# Altium schematic sheet 08 - MICROHEADERS - JX1 and JX2.SchDoc("08 - MICROHEADERS - JX1 and JX2")
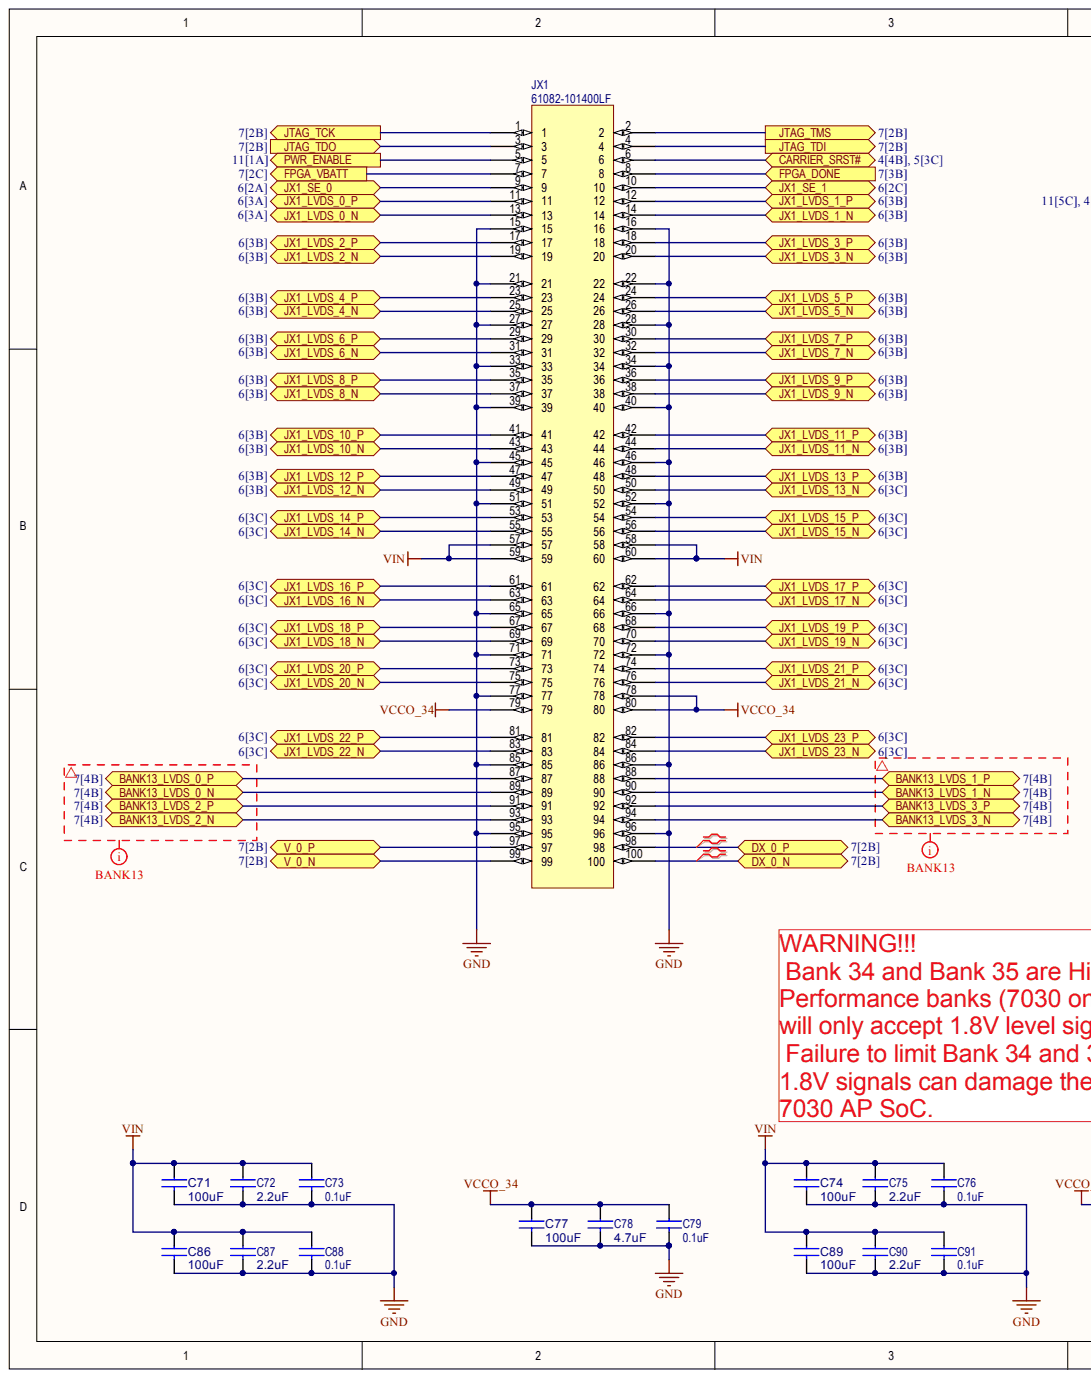
[diagram p0: 08 - MICROHEADERS - JX1 and JX2.SchDoc, left]
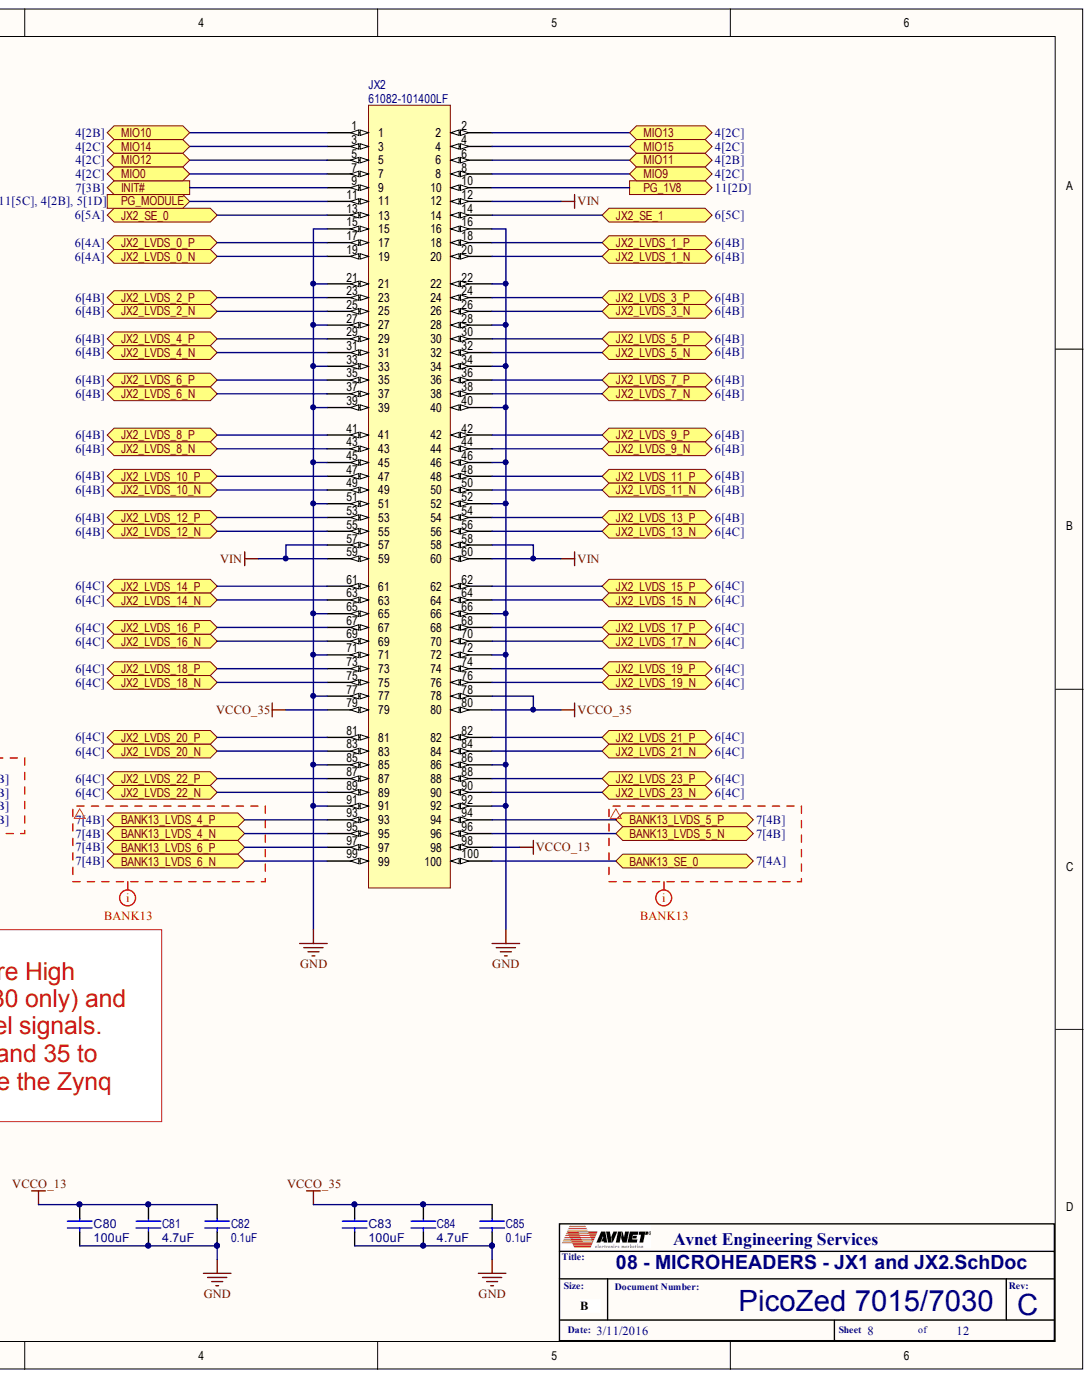
[diagram p1: 08 - MICROHEADERS - JX1 and JX2.SchDoc, right]

## Parts
C71  100uF  [C1210]
C72  2.2uF  [C0402]
C73  value 0.1uF  [C0201]  (hidden comment: PC522)
C74  100uF  [C1210]
C75  2.2uF  [C0402]
C76  value 0.1uF  [C0201]  (hidden comment: PC522)
C77  100uF  [C1210]
C78  4.7uF  [C0402]
C79  value 0.1uF  [C0201]  (hidden comment: PC522)
C80  100uF  [C1210]
C81  4.7uF  [C0402]
C82  value 0.1uF  [C0201]  (hidden comment: PC522)
C83  100uF  [C1210]
C84  4.7uF  [C0402]
C85  value 0.1uF  [C0201]  (hidden comment: PC522)
C86  100uF  [C1210]
C87  2.2uF  [C0402]
C88  value 0.1uF  [C0201]  (hidden comment: PC522)
C89  100uF  [C1210]
C90  2.2uF  [C0402]
C91  value 0.1uF  [C0201]  (hidden comment: PC522)
JX1  61082-101400LF  [61082-101400LF]
JX2  61082-101400LF  [61082-101400LF]

## Ports
BANK13_LVDS_0_N, BANK13_LVDS_0_P, BANK13_LVDS_1_N, BANK13_LVDS_1_P, BANK13_LVDS_2_N, BANK13_LVDS_2_P, BANK13_LVDS_3_N, BANK13_LVDS_3_P, BANK13_LVDS_4_N, BANK13_LVDS_4_P, BANK13_LVDS_5_N, BANK13_LVDS_5_P, BANK13_LVDS_6_N, BANK13_LVDS_6_P, BANK13_SE_0, CARRIER_SRST#, DX_0_N, DX_0_P, FPGA_DONE, FPGA_VBATT, INIT#, JTAG_TCK, JTAG_TDI, JTAG_TDO, JTAG_TMS, JX1_LVDS_0_N, JX1_LVDS_0_P, JX1_LVDS_1_N, JX1_LVDS_1_P, JX1_LVDS_2_N, JX1_LVDS_2_P, JX1_LVDS_3_N, JX1_LVDS_3_P, JX1_LVDS_4_N, JX1_LVDS_4_P, JX1_LVDS_5_N, JX1_LVDS_5_P, JX1_LVDS_6_N, JX1_LVDS_6_P, JX1_LVDS_7_N, JX1_LVDS_7_P, JX1_LVDS_8_N, JX1_LVDS_8_P, JX1_LVDS_9_N, JX1_LVDS_9_P, JX1_LVDS_10_N, JX1_LVDS_10_P, JX1_LVDS_11_N, JX1_LVDS_11_P, JX1_LVDS_12_N, JX1_LVDS_12_P, JX1_LVDS_13_N, JX1_LVDS_13_P, JX1_LVDS_14_N, JX1_LVDS_14_P, JX1_LVDS_15_N, JX1_LVDS_15_P, JX1_LVDS_16_N, JX1_LVDS_16_P, JX1_LVDS_17_N, JX1_LVDS_17_P, JX1_LVDS_18_N, JX1_LVDS_18_P, JX1_LVDS_19_N, JX1_LVDS_19_P, JX1_LVDS_20_N, JX1_LVDS_20_P, JX1_LVDS_21_N, JX1_LVDS_21_P, JX1_LVDS_22_N, JX1_LVDS_22_P, JX1_LVDS_23_N, JX1_LVDS_23_P, JX1_SE_0, JX1_SE_1, JX2_LVDS_0_N, JX2_LVDS_0_P, JX2_LVDS_1_N, JX2_LVDS_1_P, JX2_LVDS_2_N, JX2_LVDS_2_P, JX2_LVDS_3_N, JX2_LVDS_3_P, JX2_LVDS_4_N, JX2_LVDS_4_P, JX2_LVDS_5_N, JX2_LVDS_5_P, JX2_LVDS_6_N, JX2_LVDS_6_P, JX2_LVDS_7_N, JX2_LVDS_7_P, JX2_LVDS_8_N, JX2_LVDS_8_P, JX2_LVDS_9_N, JX2_LVDS_9_P, JX2_LVDS_10_N, JX2_LVDS_10_P, JX2_LVDS_11_N, JX2_LVDS_11_P, JX2_LVDS_12_N, JX2_LVDS_12_P, JX2_LVDS_13_N, JX2_LVDS_13_P, JX2_LVDS_14_N, JX2_LVDS_14_P, JX2_LVDS_15_N, JX2_LVDS_15_P, JX2_LVDS_16_N, JX2_LVDS_16_P, JX2_LVDS_17_N, JX2_LVDS_17_P, JX2_LVDS_18_N, JX2_LVDS_18_P, JX2_LVDS_19_N, JX2_LVDS_19_P, JX2_LVDS_20_N, JX2_LVDS_20_P, JX2_LVDS_21_N, JX2_LVDS_21_P, JX2_LVDS_22_N, JX2_LVDS_22_P, JX2_LVDS_23_N, JX2_LVDS_23_P, JX2_SE_0, JX2_SE_1, MIO0, MIO9, MIO10, MIO11, MIO12, MIO13, MIO14, MIO15, PG_1V8, PG_MODULE, PWR_ENABLE, V_0_N, V_0_P

## Nets
GND: C71.2 C72.2 C73.2 C74.2 C75.2 C76.2 C77.2 C78.2 C79.2 C80.2 C81.2 C82.2 C83.2 C84.2 C85.2 C86.2 C87.2 C88.2 C89.2 C90.2 C91.2 JX1.15 JX1.16 JX1.21 JX1.22 JX1.27 JX1.28 JX1.33 JX1.34 JX1.39 JX1.40 JX1.45 JX1.46 JX1.51 JX1.52 JX1.65 JX1.66 JX1.71 JX1.72 JX1.77 JX1.85 JX1.86 JX1.95 JX1.96 JX2.15 JX2.16 JX2.21 JX2.22 JX2.27 JX2.28 JX2.33 JX2.34 JX2.39 JX2.40 JX2.45 JX2.46 JX2.51 JX2.52 JX2.65 JX2.66 JX2.71 JX2.72 JX2.77 JX2.85 JX2.86 JX2.91 JX2.92
VCCO_13: C80.1 C81.1 C82.1 JX2.98
VCCO_34: C77.1 C78.1 C79.1 JX1.78 JX1.79 JX1.80
VCCO_35: C83.1 C84.1 C85.1 JX2.78 JX2.79 JX2.80
VIN: C71.1 C72.1 C73.1 C74.1 C75.1 C76.1 C86.1 C87.1 C88.1 C89.1 C90.1 C91.1 JX1.57 JX1.58 JX1.59 JX1.60 JX2.12 JX2.57 JX2.58 JX2.59 JX2.60
BANK13_LVDS_0_N: JX1.89 ; port BANK13_LVDS_0_N
BANK13_LVDS_0_P: JX1.87 ; port BANK13_LVDS_0_P
BANK13_LVDS_1_N: JX1.90 ; port BANK13_LVDS_1_N
BANK13_LVDS_1_P: JX1.88 ; port BANK13_LVDS_1_P
BANK13_LVDS_2_N: JX1.93 ; port BANK13_LVDS_2_N
BANK13_LVDS_2_P: JX1.91 ; port BANK13_LVDS_2_P
BANK13_LVDS_3_N: JX1.94 ; port BANK13_LVDS_3_N
BANK13_LVDS_3_P: JX1.92 ; port BANK13_LVDS_3_P
BANK13_LVDS_4_N: JX2.95 ; port BANK13_LVDS_4_N
BANK13_LVDS_4_P: JX2.93 ; port BANK13_LVDS_4_P
BANK13_LVDS_5_N: JX2.96 ; port BANK13_LVDS_5_N
BANK13_LVDS_5_P: JX2.94 ; port BANK13_LVDS_5_P
BANK13_LVDS_6_N: JX2.99 ; port BANK13_LVDS_6_N
BANK13_LVDS_6_P: JX2.97 ; port BANK13_LVDS_6_P
BANK13_SE_0: JX2.100 ; port BANK13_SE_0
CARRIER_SRST#: JX1.6 ; port CARRIER_SRST#
DX_0_N: JX1.100 ; port DX_0_N
DX_0_P: JX1.98 ; port DX_0_P
FPGA_DONE: JX1.8 ; port FPGA_DONE
FPGA_VBATT: JX1.7 ; port FPGA_VBATT
INIT#: JX2.9 ; port INIT#
JTAG_TCK: JX1.1 ; port JTAG_TCK
JTAG_TDI: JX1.4 ; port JTAG_TDI
JTAG_TDO: JX1.3 ; port JTAG_TDO
JTAG_TMS: JX1.2 ; port JTAG_TMS
JX1_LVDS_0_N: JX1.13 ; port JX1_LVDS_0_N
JX1_LVDS_0_P: JX1.11 ; port JX1_LVDS_0_P
JX1_LVDS_1_N: JX1.14 ; port JX1_LVDS_1_N
JX1_LVDS_1_P: JX1.12 ; port JX1_LVDS_1_P
JX1_LVDS_2_N: JX1.19 ; port JX1_LVDS_2_N
JX1_LVDS_2_P: JX1.17 ; port JX1_LVDS_2_P
JX1_LVDS_3_N: JX1.20 ; port JX1_LVDS_3_N
JX1_LVDS_3_P: JX1.18 ; port JX1_LVDS_3_P
JX1_LVDS_4_N: JX1.25 ; port JX1_LVDS_4_N
JX1_LVDS_4_P: JX1.23 ; port JX1_LVDS_4_P
JX1_LVDS_5_N: JX1.26 ; port JX1_LVDS_5_N
JX1_LVDS_5_P: JX1.24 ; port JX1_LVDS_5_P
JX1_LVDS_6_N: JX1.31 ; port JX1_LVDS_6_N
JX1_LVDS_6_P: JX1.29 ; port JX1_LVDS_6_P
JX1_LVDS_7_N: JX1.32 ; port JX1_LVDS_7_N
JX1_LVDS_7_P: JX1.30 ; port JX1_LVDS_7_P
JX1_LVDS_8_N: JX1.37 ; port JX1_LVDS_8_N
JX1_LVDS_8_P: JX1.35 ; port JX1_LVDS_8_P
JX1_LVDS_9_N: JX1.38 ; port JX1_LVDS_9_N
JX1_LVDS_9_P: JX1.36 ; port JX1_LVDS_9_P
JX1_LVDS_10_N: JX1.43 ; port JX1_LVDS_10_N
JX1_LVDS_10_P: JX1.41 ; port JX1_LVDS_10_P
JX1_LVDS_11_N: JX1.44 ; port JX1_LVDS_11_N
JX1_LVDS_11_P: JX1.42 ; port JX1_LVDS_11_P
JX1_LVDS_12_N: JX1.49 ; port JX1_LVDS_12_N
JX1_LVDS_12_P: JX1.47 ; port JX1_LVDS_12_P
JX1_LVDS_13_N: JX1.50 ; port JX1_LVDS_13_N
JX1_LVDS_13_P: JX1.48 ; port JX1_LVDS_13_P
JX1_LVDS_14_N: JX1.55 ; port JX1_LVDS_14_N
JX1_LVDS_14_P: JX1.53 ; port JX1_LVDS_14_P
JX1_LVDS_15_N: JX1.56 ; port JX1_LVDS_15_N
JX1_LVDS_15_P: JX1.54 ; port JX1_LVDS_15_P
JX1_LVDS_16_N: JX1.63 ; port JX1_LVDS_16_N
JX1_LVDS_16_P: JX1.61 ; port JX1_LVDS_16_P
JX1_LVDS_17_N: JX1.64 ; port JX1_LVDS_17_N
JX1_LVDS_17_P: JX1.62 ; port JX1_LVDS_17_P
JX1_LVDS_18_N: JX1.69 ; port JX1_LVDS_18_N
JX1_LVDS_18_P: JX1.67 ; port JX1_LVDS_18_P
JX1_LVDS_19_N: JX1.70 ; port JX1_LVDS_19_N
JX1_LVDS_19_P: JX1.68 ; port JX1_LVDS_19_P
JX1_LVDS_20_N: JX1.75 ; port JX1_LVDS_20_N
JX1_LVDS_20_P: JX1.73 ; port JX1_LVDS_20_P
JX1_LVDS_21_N: JX1.76 ; port JX1_LVDS_21_N
JX1_LVDS_21_P: JX1.74 ; port JX1_LVDS_21_P
JX1_LVDS_22_N: JX1.83 ; port JX1_LVDS_22_N
JX1_LVDS_22_P: JX1.81 ; port JX1_LVDS_22_P
JX1_LVDS_23_N: JX1.84 ; port JX1_LVDS_23_N
JX1_LVDS_23_P: JX1.82 ; port JX1_LVDS_23_P
JX1_SE_0: JX1.9 ; port JX1_SE_0
JX1_SE_1: JX1.10 ; port JX1_SE_1
JX2_LVDS_0_N: JX2.19 ; port JX2_LVDS_0_N
JX2_LVDS_0_P: JX2.17 ; port JX2_LVDS_0_P
JX2_LVDS_1_N: JX2.20 ; port JX2_LVDS_1_N
JX2_LVDS_1_P: JX2.18 ; port JX2_LVDS_1_P
JX2_LVDS_2_N: JX2.25 ; port JX2_LVDS_2_N
JX2_LVDS_2_P: JX2.23 ; port JX2_LVDS_2_P
JX2_LVDS_3_N: JX2.26 ; port JX2_LVDS_3_N
JX2_LVDS_3_P: JX2.24 ; port JX2_LVDS_3_P
JX2_LVDS_4_N: JX2.31 ; port JX2_LVDS_4_N
JX2_LVDS_4_P: JX2.29 ; port JX2_LVDS_4_P
JX2_LVDS_5_N: JX2.32 ; port JX2_LVDS_5_N
JX2_LVDS_5_P: JX2.30 ; port JX2_LVDS_5_P
JX2_LVDS_6_N: JX2.37 ; port JX2_LVDS_6_N
JX2_LVDS_6_P: JX2.35 ; port JX2_LVDS_6_P
JX2_LVDS_7_N: JX2.38 ; port JX2_LVDS_7_N
JX2_LVDS_7_P: JX2.36 ; port JX2_LVDS_7_P
JX2_LVDS_8_N: JX2.43 ; port JX2_LVDS_8_N
JX2_LVDS_8_P: JX2.41 ; port JX2_LVDS_8_P
JX2_LVDS_9_N: JX2.44 ; port JX2_LVDS_9_N
JX2_LVDS_9_P: JX2.42 ; port JX2_LVDS_9_P
JX2_LVDS_10_N: JX2.49 ; port JX2_LVDS_10_N
JX2_LVDS_10_P: JX2.47 ; port JX2_LVDS_10_P
JX2_LVDS_11_N: JX2.50 ; port JX2_LVDS_11_N
JX2_LVDS_11_P: JX2.48 ; port JX2_LVDS_11_P
JX2_LVDS_12_N: JX2.55 ; port JX2_LVDS_12_N
JX2_LVDS_12_P: JX2.53 ; port JX2_LVDS_12_P
JX2_LVDS_13_N: JX2.56 ; port JX2_LVDS_13_N
JX2_LVDS_13_P: JX2.54 ; port JX2_LVDS_13_P
JX2_LVDS_14_N: JX2.63 ; port JX2_LVDS_14_N
JX2_LVDS_14_P: JX2.61 ; port JX2_LVDS_14_P
JX2_LVDS_15_N: JX2.64 ; port JX2_LVDS_15_N
JX2_LVDS_15_P: JX2.62 ; port JX2_LVDS_15_P
JX2_LVDS_16_N: JX2.69 ; port JX2_LVDS_16_N
JX2_LVDS_16_P: JX2.67 ; port JX2_LVDS_16_P
JX2_LVDS_17_N: JX2.70 ; port JX2_LVDS_17_N
JX2_LVDS_17_P: JX2.68 ; port JX2_LVDS_17_P
JX2_LVDS_18_N: JX2.75 ; port JX2_LVDS_18_N
JX2_LVDS_18_P: JX2.73 ; port JX2_LVDS_18_P
JX2_LVDS_19_N: JX2.76 ; port JX2_LVDS_19_N
JX2_LVDS_19_P: JX2.74 ; port JX2_LVDS_19_P
JX2_LVDS_20_N: JX2.83 ; port JX2_LVDS_20_N
JX2_LVDS_20_P: JX2.81 ; port JX2_LVDS_20_P
JX2_LVDS_21_N: JX2.84 ; port JX2_LVDS_21_N
JX2_LVDS_21_P: JX2.82 ; port JX2_LVDS_21_P
JX2_LVDS_22_N: JX2.89 ; port JX2_LVDS_22_N
JX2_LVDS_22_P: JX2.87 ; port JX2_LVDS_22_P
JX2_LVDS_23_N: JX2.90 ; port JX2_LVDS_23_N
JX2_LVDS_23_P: JX2.88 ; port JX2_LVDS_23_P
JX2_SE_0: JX2.13 ; port JX2_SE_0
JX2_SE_1: JX2.14 ; port JX2_SE_1
MIO0: JX2.7 ; port MIO0
MIO9: JX2.8 ; port MIO9
MIO10: JX2.1 ; port MIO10
MIO11: JX2.6 ; port MIO11
MIO12: JX2.5 ; port MIO12
MIO13: JX2.2 ; port MIO13
MIO14: JX2.3 ; port MIO14
MIO15: JX2.4 ; port MIO15
PG_1V8: JX2.10 ; port PG_1V8
PG_MODULE: JX2.11 ; port PG_MODULE
PWR_ENABLE: JX1.5 ; port PWR_ENABLE
V_0_N: JX1.99 ; port V_0_N
V_0_P: JX1.97 ; port V_0_P
N00625:
N00626:
N00627:
N00628:

## Library data of the parts
C71 C74 C86 C89 = AVPC-0000209: CAP SM 100uF 16V 20% X5R C1206; PartCode: PC; AsyTxt: PC209; Database Table Name: Passive-Cap$; MFG: Taiyo Yuden; MFG Code: TY; MFGPN: EMK325ABJ107MM-T; Power: 0; Temp: I; Volt: 6.3V; Availability: 180K-AV; AVLNo: AVPC-0000209; CLASSNAME: DDR_TERM; Component Kind: Standard; ComponentLink1Description: Datasheet; Cost: .41; Device: CAP; Dielectric: X5R; PkgType: SM; Received: 0; RoHS: Yes; Size: 9.11; Status: Preliminary; Tol: 20%; Value: 100uF; ComponentLink1URL: C:\AVDBLIB\DATASHEETS\GRM31CR60J107ME39.pdf; Library Name: Avnet_DBlib.DbLib
C72 C75 C87 C90 = AVPC-0000499: CAP SM 2.2uF 16V 20% X5R C0402; PartCode: PC; AsyTxt: PC499; Database Table Name: Passive-Cap$; MFG: Taiyo Yuden; MFG Code: TAIYO; MFGPN: EMK105ABJ225MV-F; Power: 0; Temp: I; Availability: 140K-DK; AVLNo: AVPC-0000499; Component Kind: Standard; ComponentLink1Description: Datasheet; Cost: .46; Device: CAP; Dielectric: X5R; PkgType: SM; Received: 0; RoHS: Yes; Size: 1.9; Status: Preliminary; Tol: 20%; Value: 2.2uF; Volt: 16V; ComponentLink1URL: C:\AVDBLIB\DATASHEETS\murata_caps_c02e.pdf; Library Name: Avnet_DBlib.DbLib
C73 C76 C79 C82 C85 C88 C91 = AVPC-0000522: CAP SM 0.1uF 16V 10% X5R C0201; PartCode: PC; Database Table Name: Passive-Cap$; MFG: TDK; MFGPN: C0603X5R1C104K030BC; Temp: I; AsyTxt: PC522; CLASSNAME: U11_BYP; Component Kind: Standard; ComponentLink1Description: Datasheet; Device: CAP; Dielectric: X5R; MFG Code: TDK; PkgType: SM; RoHS: Yes; Status: Preliminary; Tol: 10%; Volt: 16V; Library Name: Avnet_DBlib.DbLib
C77 C80 C83 = AVPC-0000209: CAP SM 100uF 6.3V 20% X5R C1206; PartCode: PC; AsyTxt: PC209; Database Table Name: Passive-Cap$; MFG: Murata; MFG Code: MUR; MFGPN: GRM32EF50J107ZE20K; Power: 0; Temp: I; Availability: 180K-AV; AVLNo: AVPC-0000209; CLASSNAME: DDR_TERM; Component Kind: Standard; ComponentLink1Description: Datasheet; Cost: .41; Device: CAP; Dielectric: X5R; PkgType: SM; Received: 0; RoHS: Yes; Size: 9.11; Status: Preliminary; Tol: 20%; Value: 100uF; Volt: 6.3V; ComponentLink1URL: C:\AVDBLIB\DATASHEETS\GRM31CR60J107ME39.pdf; Library Name: Avnet_DBlib.DbLib
C78 C81 C84 = AVPC-0000499: CAP SM 4.7uF 6.3V 20% X5R C0402; PartCode: PC; AsyTxt: PC499; Database Table Name: Passive-Cap$; MFG: Murata; MFG Code: AVX; MFGPN: GRM155R60J475ME87D; Power: 0; Temp: I; Availability: 140K-DK; AVLNo: AVPC-0000499; Component Kind: Standard; ComponentLink1Description: Datasheet; Cost: .46; Device: CAP; Dielectric: X5R; PkgType: SM; Received: 0; RoHS: Yes; Size: 1.9; Status: Preliminary; Tol: 20%; Value: 4.7uF; Volt: 6.3V; ComponentLink1URL: C:\AVDBLIB\DATASHEETS\murata_caps_c02e.pdf; Library Name: Avnet_DBlib.DbLib
JX1 JX2 = 61082-101400LF: Microheader 100 pos Plug .8mm; AVLNo: AVEH-0000140; MFG: FCI; Temp: C; Component Kind: Standard; Library Name: Zynq_Z010_ZedStick.SchLib; MFG Code: FCI; MFGPN: 61082-101400LF
